# Altium schematic sheet LH-Cloud-Power.SchDoc(LH-Cloud-Power)
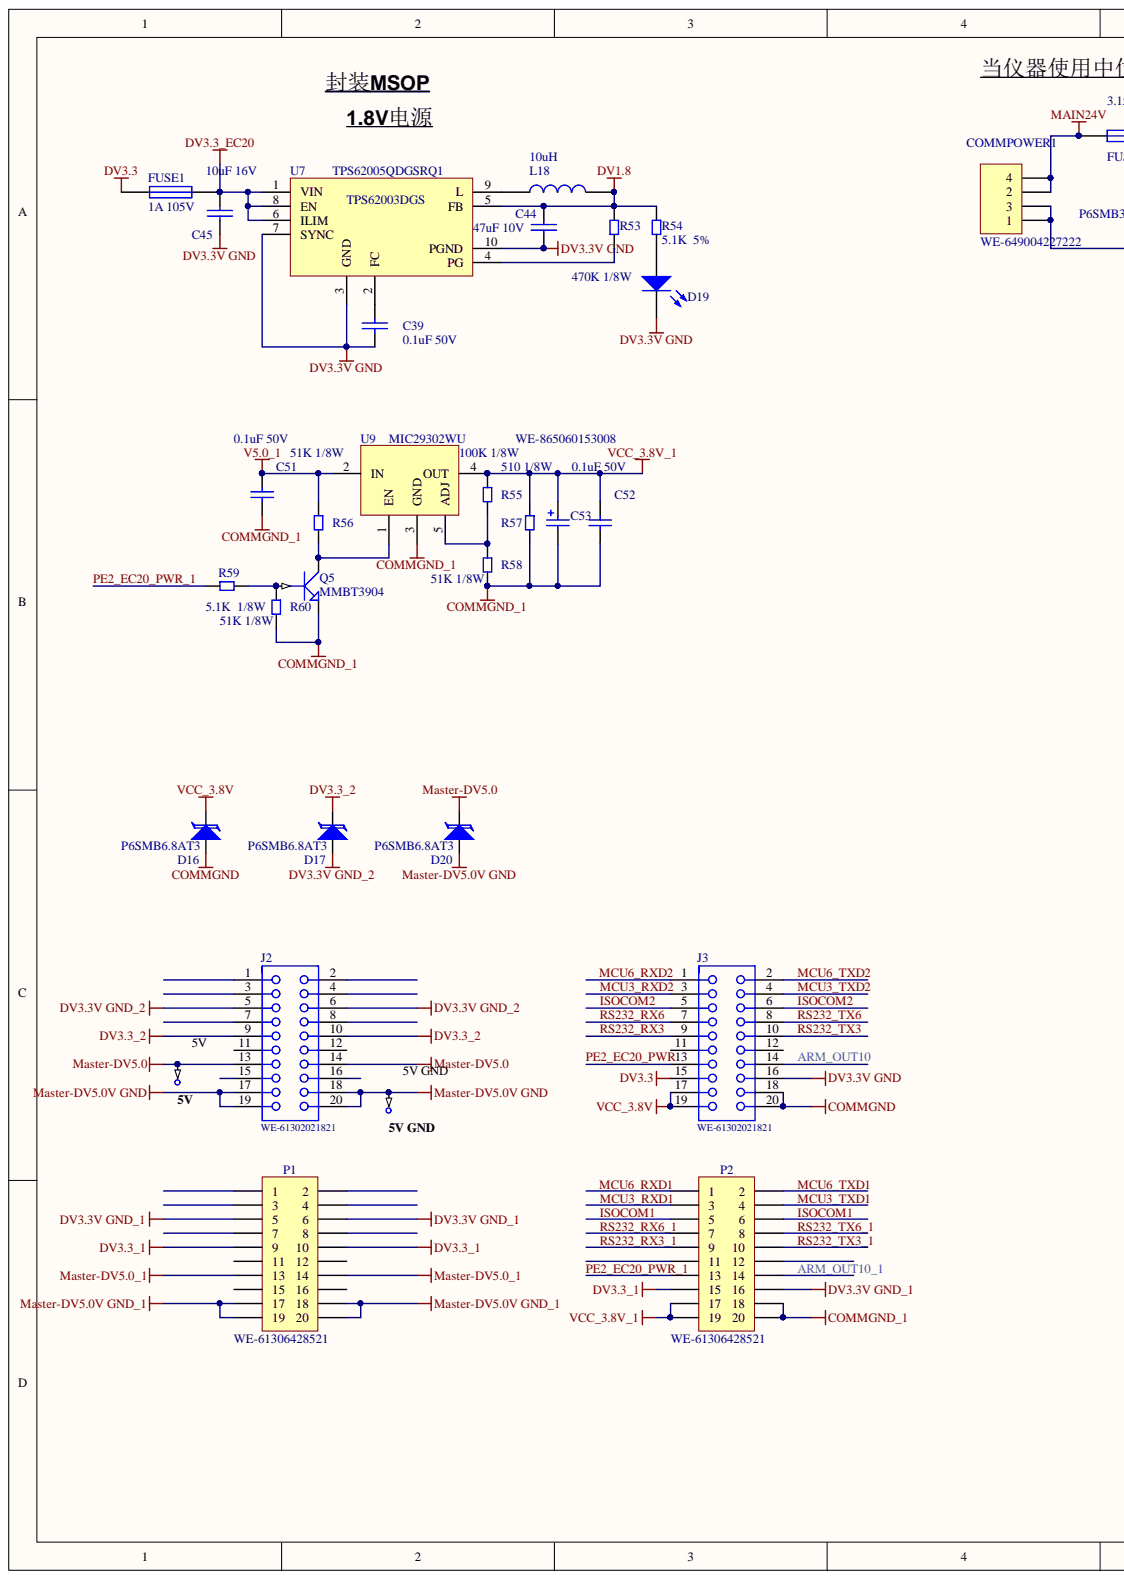
[diagram p0: LH-Cloud-Power.SchDoc, left]
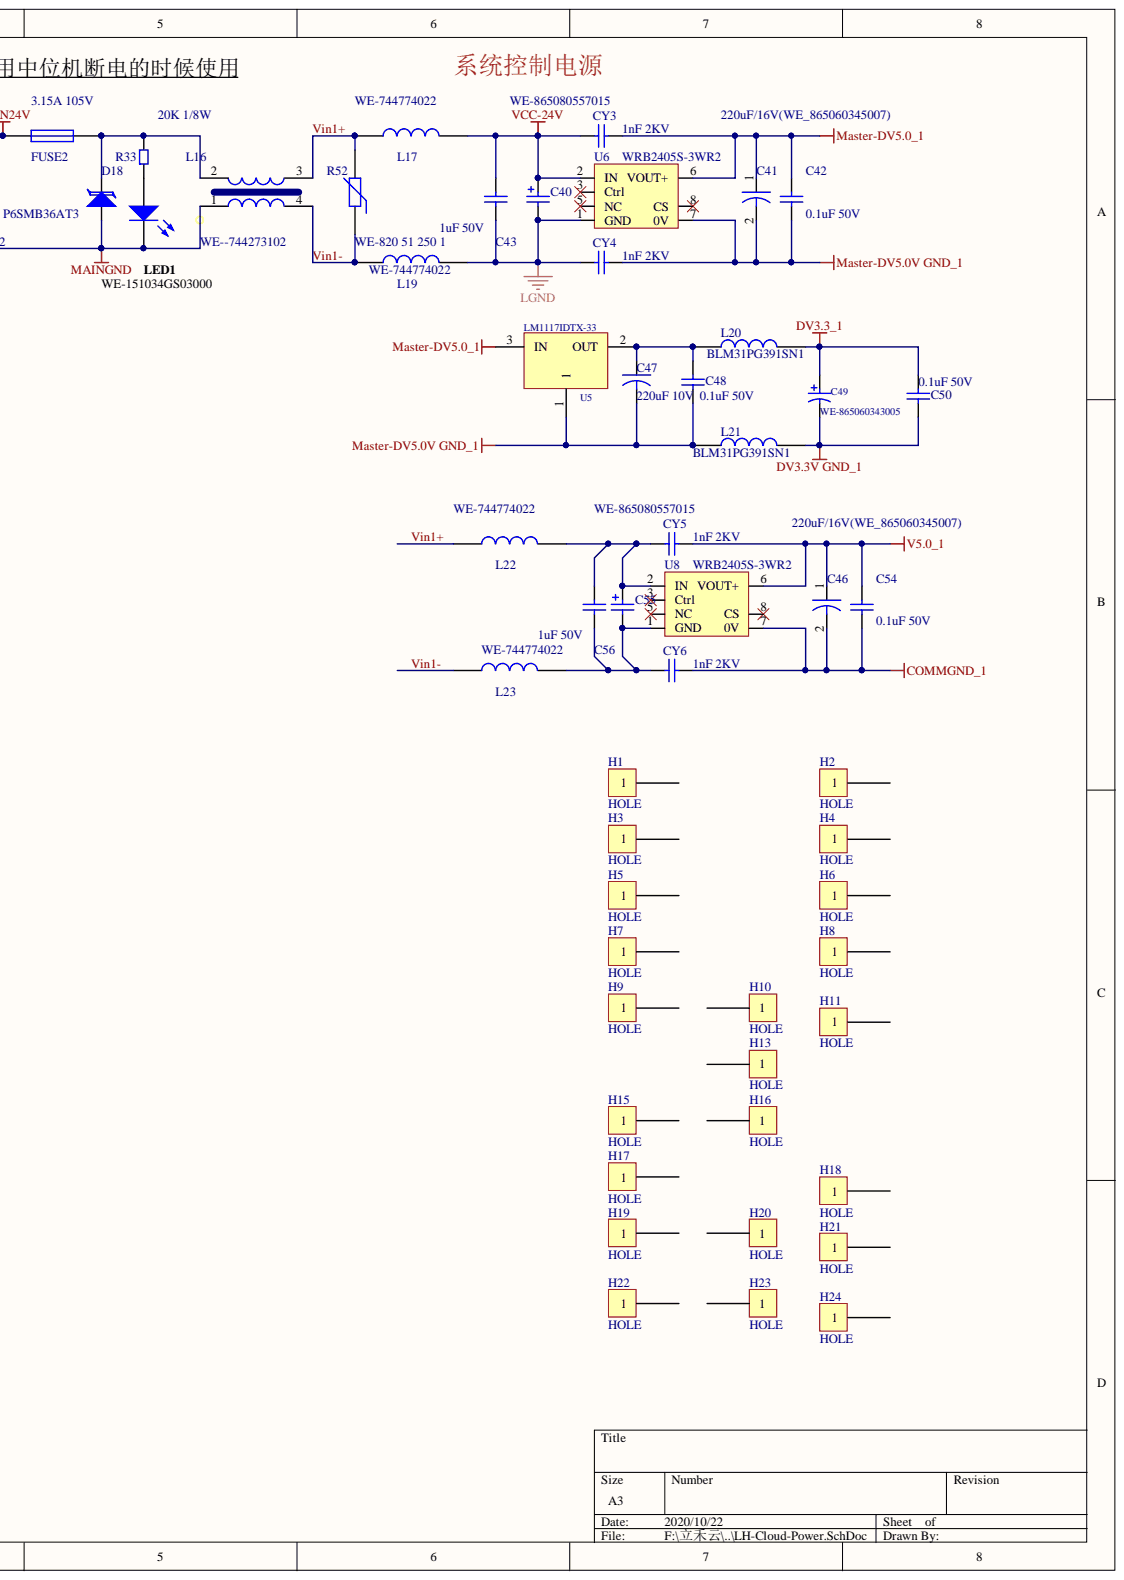
[diagram p1: LH-Cloud-Power.SchDoc, right]

## Parts
5V  5V  [TestPin]  (no BOM)
5V_GND  5V GND  [TestPin]  (no BOM)
C39  0.1uF 50V  value 0.1uF  [C0805]
C40  WE-865080557015  [WCAP-ASLI_10x10.5]
C41  220uF/16V(WE_865060345007)  [WE-ASLL-6.3*7.7-865060345007(220/16V)]
C42  0.1uF 50V  [C0805_104/50V]
C43  1uF 50V  [C0805_105/50V]
C44  47uF 10V  [C0805]
C45  10uF 16V  [CAPBCASE_106/16V]
C46  220uF/16V(WE_865060345007)  [WE-ASLL-6.3*7.7-865060345007(220/16V)]
C47  220uF 10V  [CAPDCASE_E]
C48  0.1uF 50V  [C0603_104/50V]
C49  WE-865060343005  [CAPF63_100UF/16V]
C50  0.1uF 50V  [C0603_104/50V]
C51  0.1uF 50V  [C0805_104/50V]
C52  0.1uF 50V  [C0805_104/50V]
C53  WE-865060153008  [WCAP-ASLL_8x10.5]
C54  0.1uF 50V  [C0805_104/50V]
C55  WE-865080557015  [WCAP-ASLI_10x10.5]
C56  1uF 50V  [C0805_105/50V]
COMMPOWER1  WE-649004227222  [M5557D420P2X2-90]
CY3  1nF 2KV  [C1206_102/2KV]
CY4  1nF 2KV  [C1206_102/2KV]
CY5  1nF 2KV  [C1206_102/2KV]
CY6  1nF 2KV  [C1206_102/2KV]
D16  P6SMB6.8AT3  [DIODESMB_DIODESMB]
D17  P6SMB6.8AT3  [DIODESMB_DIODESMB]
D18  P6SMB36AT3  [DIODESMB_DIODESMB]
D19  LED2  [LED_0805]  (value not drawn)
D20  P6SMB6.8AT3  [DIODESMB_DIODESMB]
FUSE1  1A 105V  [1206]
FUSE2  3.15A 105V  [FUSE2410ZUO]
H1  HOLE  [HOLE3.5]
H2  HOLE  [HOLE3.5]
H3  HOLE  [HOLE3.5]
H4  HOLE  [HOLE3.5]
H5  HOLE  [HOLE3.5]
H6  HOLE  [HOLE3.5]
H7  HOLE  [HOLE3.5]
H8  HOLE  [HOLE3.5]
H9  HOLE  [HOLE3.5]
H10  HOLE  [HOLE3.5]
H11  HOLE  [HOLE3.5]
H13  HOLE  [HOLE3.5]
H15  HOLE  [HOLE3.5]
H16  HOLE  [HOLE3.5]
H17  HOLE  [HOLE3.5]
H18  HOLE  [HOLE3.5]
H19  HOLE  [HOLE3.5]
H20  HOLE  [HOLE3.5]
H21  HOLE  [HOLE3.5]
H22  HOLE  [HOLE3.5]
H23  HOLE  [HOLE3.5]
H24  HOLE  [HOLE3.5]
J2  WE-61302021821  [61302021821]
J3  WE-61302021821  [61302021821]
L16  WE--744273102  [WE-SL5-SMD_744273501]
L17  WE-744774022  [WE-PD-SMD5848-2.2uH/4.6A_744774022]
L18  10uH  [WE-LQS-SMD4018_10uH]
L19  WE-744774022  [WE-PD-SMD5848-2.2uH/4.6A_744774022]
L20  BLM31PG391SN1  [1206_BLM31PG391SN1]
L21  BLM31PG391SN1  [1206_BLM31PG391SN1]
L22  WE-744774022  [WE-PD-SMD5848-2.2uH/4.6A_744774022]
L23  WE-744774022  [WE-PD-SMD5848-2.2uH/4.6A_744774022]
LED1  WE-151034GS03000  [LED_3MM]
P1  value WE-61306428521  [HIF3FC20PA254DSA]  (hidden comment: HIF3FC-2*10-S)
P2  value WE-61306428521  [HIF3FC20PA254DSA]  (hidden comment: HIF3FC-2*10-S)
Q5  MMBT3904  [SOT-23_MMBT3904]
R33  20K 1/8W  [RES0805_203]
R52  WE-820 51 250 1  [WE-VD-10_820512501]
R53  470K 1/8W  [RES0805]
R54  5.1K  5%  [RES0603]
R55  100K 1/8W  [RES0805]
R56  51K 1/8W  [RES0805]
R57  510 1/8W  [RES0805]
R58  51K 1/8W  [RES0805]
R59  5.1K  1/8W  [RES0805]
R60  51K 1/8W  [RES0805]
U5  LM1117IDTX-33  [78M05_TO252]
U6  WRB2405S-3WR2  [WRB2405S-3WR2]
U7  TPS62005QDGSRQ1  [VSSOP10]
U8  WRB2405S-3WR2  [WRB2405S-3WR2]
U9  MIC29302WU  [TO263P5_MIC29302WU]

## Nets
COMMGND: D16.1 J3.18 J3.20
COMMGND_1: C46.2 C51.2 C52.2 C53.1 C54.2 CY6.2 P2.18 P2.20 Q5.2 R57.2 R58.2 R60.2 U8.7(0V) U9.3(GND)
DV1.8: C44.1 L18.2 R53.2 R54.1 U7.5(FB)
DV3.3: FUSE1.2 J3.15
DV3.3_1: C49.1 C50.1 L20.2 P1.9 P1.10 P2.15
DV3.3_2: D17.2 J2.9 J2.10
DV3.3_EC20: C45.1 FUSE1.1 U7.1(VIN) U7.6(ILIM) U7.8(EN)
DV3.3V GND: C39.2 C44.2 C45.2 D19.2 J3.16 U7.3(GND) U7.7(SYNC) U7.10(PGND)
DV3.3V GND_1: C49.2 C50.2 L21.2 P1.5 P1.6 P2.16
DV3.3V GND_2: D17.1 J2.5 J2.6
LGND: C40.1 C43.2 CY4.1 L19.2 U6.1(GND)
MAIN24V: COMMPOWER1.2 COMMPOWER1.4 FUSE2.1
MAINGND: COMMPOWER1.1 COMMPOWER1.3 D18.1 L16.1 LED1.2
Master-DV5.0: 5V.1 D20.2 J2.13 J2.14
Master-DV5.0_1: C41.1 C42.1 CY3.2 P1.13 P1.14 U5.3 U6.6(VOUT+)
Master-DV5.0V GND: 5V_GND.1 D20.1 J2.17 J2.18 J2.19 J2.20
Master-DV5.0V GND_1: C41.2 C42.2 C47.2 C48.2 CY4.2 L21.1 P1.17 P1.18 P1.19 P1.20 U5.1 U6.7(0V)
V5.0_1: C46.1 C51.1 C54.1 CY5.2 R56.1 U8.6(VOUT+) U9.2(IN)
VCC-24V: C40.2 C43.1 CY3.1 L17.2 U6.2(IN)
VCC_3.8V: D16.2 J3.17 J3.19
VCC_3.8V_1: C52.1 C53.2 P2.17 P2.19 R55.1 R57.1 U9.4(OUT)
ARM_OUT10: J3.14
ARM_OUT10_1: P2.14
ISOCOM1: P2.5 P2.6
ISOCOM2: J3.5 J3.6
MCU3_RXD1: P2.3
MCU3_RXD2: J3.3
MCU3_TXD1: P2.4
MCU3_TXD2: J3.4
MCU6_RXD1: P2.1
MCU6_RXD2: J3.1
MCU6_TXD1: P2.2
MCU6_TXD2: J3.2
PE2_EC20_PWR: J3.13
PE2_EC20_PWR_1: P2.13 R59.1
RS232_RX3: J3.9
RS232_RX3_1: P2.9
RS232_RX6: J3.7
RS232_RX6_1: P2.7
RS232_TX3: J3.10
RS232_TX3_1: P2.10
RS232_TX6: J3.8
RS232_TX6_1: P2.8
Vin1+: L16.3 L17.1 L22.1 R52.2
Vin1-: L16.4 L19.1 L23.1 R52.1
NetC39_1: C39.1 U7.2(FC)
NetC47_1: C47.1 C48.1 L20.1 U5.2
NetC55_1: C55.1 C56.2 CY6.1 L23.2 U8.1(GND)
NetC55_2: C55.2 C56.1 CY5.1 L22.2 U8.2(IN)
NetD18_2: D18.2 FUSE2.2 L16.2 R33.2
NetD19_1: D19.1 R54.2
NetL18_1: L18.1 U7.9(L)
NetLED1_1: LED1.1 R33.1
NetQ5_1: Q5.1 R59.2 R60.1
NetQ5_3: Q5.3 R56.2 U9.1(EN)
NetR53_1: R53.1 U7.4(PG)
NetR55_2: R55.2 R58.1 U9.5(ADJ)

Not connected: H1 1; H2 1; H3 1; H4 1; H5 1; H6 1; H7 1; H8 1; H9 1; H10 1; H11 1; H13 1; H15 1; H16 1; H17 1; H18 1; H19 1; H20 1; H21 1; H22 1; H23 1; H24 1; J2 1 2 3 4 7 8 11 12 15 16; J3 11 12; P1 1 2 3 4 7 8 11 12 15 16; P2 11 12; U6 3(Ctrl) 5(NC) 8(CS); U8 3(Ctrl) 5(NC) 8(CS)

## Library data of the parts
5V 5V_GND = D-006-0000: Test Point, PCB Mount with Silkscreen Box; Status: Production; Library Name: AltiumDesignLibrary.DesignLib; Category: Test-Point
C39 = CAP: Capacitor, Ceramic, 0.1uF 10% 50V 0805; MFG Part Number: C0603C104K5RACTU; Manufacturer: Kemet; Component Kind: Standard
C40 C55 = 865080557015: WCAP-ASLI Aluminum Electrolytic Capacitor, V-Chip, SMT, D10xH10.5mm, 330uF, 35V; Manufacturer: Wurth Elektronik; Technical Reference: ASEF105331M035DVCTEB000; Ripple Current: 650mA; Rated Voltage: 35VDC; Leakage Current: 115.5uA; Endurance: 2000h; Dissipation Factor: 14%; Capacitance Tolerance: +/-20%; Published Date: May 2020; Packaging: Tape and Reel; Operating Temperature: -55 to +105degC; Mounting Technology: SMT; Manufacturer Part Number: 865080557015; Library Name: WCAP-ASLI.SchLib; Height: 10.5mm; Diameter: 10mm; ComponentLink2URL: https://www.we-online.com/catalog/datasheet/865080557015.pdf; ComponentLink2Description: Datasheet; ComponentLink1URL: http://www.we-online.de; ComponentLink1Description: Manufacturer; Component Kind: Standard; Capacitance: 330uF
C41 C46 = CAPACITOR: WCAP-ASLI 50/63V Low Impedance; Component Kind: Standard
C42 C43 C51 C52 C54 C56 = Cap Semi: Capacitor (Semiconductor SIM Model); Value: 105; Simulation: CAP; Signal Integrity: Cap Semi; Published: 8-Jun-2000; PackageReference: C1206; PackageDescription: Chip Capacitor; Library Name: Miscellaneous Devices.IntLib; LatestRevisionNote: IPC-7351 Footprint Added.; LatestRevisionDate: 29-May-2009; Component Kind: Standard; Publisher: Altium Limited
C44 = CAP: Component Kind: Standard
C45 = CAP: AVX TAN; Component Kind: Standard
C47 = CAPACITOR: Capacitor, Tantalum, 220uF 10% 10V MCCT-D (7343-31); Component Kind: Standard
C48 C50 = Cap Semi: SAMSUNG  MULTILAYER  CERAMIC 5%; Value: 105; Simulation: CAP; Signal Integrity: Cap Semi; Published: 8-Jun-2000; PackageReference: C1206; PackageDescription: Chip Capacitor; Library Name: Miscellaneous Devices.IntLib; LatestRevisionNote: IPC-7351 Footprint Added.; LatestRevisionDate: 29-May-2009; Component Kind: Standard; Publisher: Altium Limited
C49 = CAP_POLAR_1: WCAP-AS5H 16V Long Life  100uF 16V; PCB3D: Cap5x6_8; Component Kind: Standard; Library Name: SpiritLevel_2E.SchLib
C53 = 865060153008: WCAP-ASLL Aluminum Electrolytic Capacitor, V-Chip, SMT, D8xH10.5mm, 470uF, 6.3V; Manufacturer: Wurth Elektronik; Technical Reference: ASEE105471M6R3DVCTEE000; Ripple Current: 500mA; Rated Voltage: 6.3VDC; Leakage Current: 29.61uA; Endurance: 5000h; Dissipation Factor: 22%; Capacitance Tolerance: +/-20%; Published Date: May 2020; Packaging: Tape and Reel; Operating Temperature: -55 to +105degC; Mounting Technology: SMT; Manufacturer Part Number: 865060153008; Library Name: WCAP-ASLL.SchLib; Height: 10.5mm; Diameter: 8mm; ComponentLink2URL: https://www.we-online.com/catalog/datasheet/865060153008.pdf; ComponentLink2Description: Datasheet; ComponentLink1URL: http://www.we-online.de; ComponentLink1Description: Manufacturer; Component Kind: Standard; Capacitance: 470uF
COMMPOWER1 = Header 4H: WR-MPC4 Dual Row Male Right Angled Header; Signal Integrity: Connector; Library Name: 2015.10.1.SCHLIB; LatestRevisionNote: Re-released for DXP Platform.; LatestRevisionDate: 17-Jul-2002; Component Kind: Standard; Publisher: Altium Limited
CY3-CY6 = Cap Semi: C1206KKX7RDBB102; Value: 105; Simulation: CAP; Signal Integrity: Cap Semi; Published: 8-Jun-2000; PackageReference: C1206; PackageDescription: Chip Capacitor; Library Name: Miscellaneous Devices.IntLib; LatestRevisionNote: IPC-7351 Footprint Added.; LatestRevisionDate: 29-May-2009; Component Kind: Standard; Publisher: Altium Limited
D16 D17 D20 = DIODE OVERVOLTAGE: 600 Watt Peak Power Zener Transient Voltage Suppressors; Component Kind: Standard
D18 = DIODE OVERVOLTAGE: Component Kind: Standard
D19 = LED2: Typical  GREEN,  GaAs LED; Published: 8-Jun-2000; PackageVersion: Feb-2002; PackageReference: 3.2X1.6X1.1; PackageDescription: SM LED; 2 Flat Leads; Library Name: Miscellaneous Devices.IntLib; LatestRevisionNote: Re-released for DXP Platform.; LatestRevisionDate: 17-Jul-2002; Component Kind: Standard; Publisher: Altium Limited
FUSE1 FUSE2 = FUSE1: Fuse; Component Kind: Standard
J2 J3 = 61302021821: WR-PHD Socket Header, THT, pitch 2.54mm, Dual Row, Vertical, 20p; Manufacturer: Wurth Elektronik; Working Voltage: 250VAC; Withstanding Voltage: 500VAC; Rated Current: 3A; Pin Number: 20; Contact Resistance: 20mOhm; Row Number: Dual; Published Date: May 2020; Pitch: 2.54mm; Packaging: Tray; Orientation: Vertical; Operating Temperature: -40 to +125degC; Mounting Technology: THT; Manufacturer Part Number: 61302021821; Library Name: WR-PHD_Socket Header_2.54mm.SchLib; Gender: Socket Header; ComponentLink2URL: https://www.we-online.com/catalog/datasheet/61302021821.pdf; ComponentLink2Description: Datasheet; ComponentLink1URL: http://www.we-online.de; ComponentLink1Description: Manufacturer; Component Kind: Standard
L16 = WE-SL5 HC: SMD Common Mode Line Filter  Z=2X500Ohm RDC=0.006Ohm I=5.0A WE--744273102; Library Name: mainboard_v1.1.SCHLIB; Component Kind: Standard
L17 L19 L22 L23 = INDUCTOR: WE-PD2 SMD Shielded Power Inductor  2.2uH; Library Name: 2015.10.1.SCHLIB; Component Kind: Standard
L18 = INDUCTOR: WE74404042100; Component Kind: Standard
L20 L21 = INDUCTOR: On-Board Type (DC) EMI Suppression Filters; Library Name: 2015.10.1.SCHLIB; Component Kind: Standard
LED1 = D-153-0003: WL-TMRW LED, Green 3mm Waterclear without stopper; Peak Wave Length: 639 nm; Brightness: 17.0 mcd; Status: Production; Mounting Technology: Surface Mount; Library Name: AltiumDesignLibrary.DesignLib; Component Kind: Standard; Color: N/A; Category: LED
P1 P2 = MHDR2X10: WR-PHD Pin Header, THT, pitch 2.54mm, Dual Row, Vertical, 64p; Library Name: Miscellaneous Connectors.IntLib; LatestRevisionNote: Re-released for DXP Platform.; LatestRevisionDate: 17-Jul-2002; Component Kind: Standard; Publisher: Altium Limited
Q5 = NPN1: NPN Transistor; Component Kind: Standard
R33 R53 R55 R57 R58 = RES2: YAGEO Chip  1%; Component Kind: Standard
R52 = Res Varistor: Varistor (Voltage-Sensitive Resistor); Published: 24-Mar-1999; PackageVersion: Mar-2003; PackageReference: 6-0805; PackageDescription: Chip Resistor 0805 Case Size; Library Name: 2015.10.1.SCHLIB; LatestRevisionNote: IPC-7351 Footprint Added.; LatestRevisionDate: 29-May-2009; Component Kind: Standard; Code_IPC: RESC2012; Publisher: Altium Limited
R54 R56 R59 R60 = RES2: Component Kind: Standard
U5 = LM1084IS-ADJ: 5A Low Dropout Possitive Regulators; PCB3D: to263; Component Kind: Standard; Library Name: SpiritLevel_2E.SchLib
U6 U8 = WRB0503S-3WR2: SINGLE OUTPUT DC-DC CONVERTER; Library Name: 2017.4.24 MainBoard.SchLib; Component Kind: Standard
U7 = TPS62003DGS: HIGH-EFFICIENCY STEP-DOWN LOW POWER DC-DC CONVERTER; Library Name: 2017.4.24 MainBoard.SchLib; Component Kind: Standard
U9 = MIC29302WU: High-Current Low-Dropout Regulators; Component Kind: Standard; Library Name: LH-Cloud-Board_V1.0.SCHLIB
H1-H11 H13 H15-H24 = HOLE: Component Kind: Standard; Library Name: Alitum Designer SCH.lib
